# Altium schematic sheet Main.SchDoc
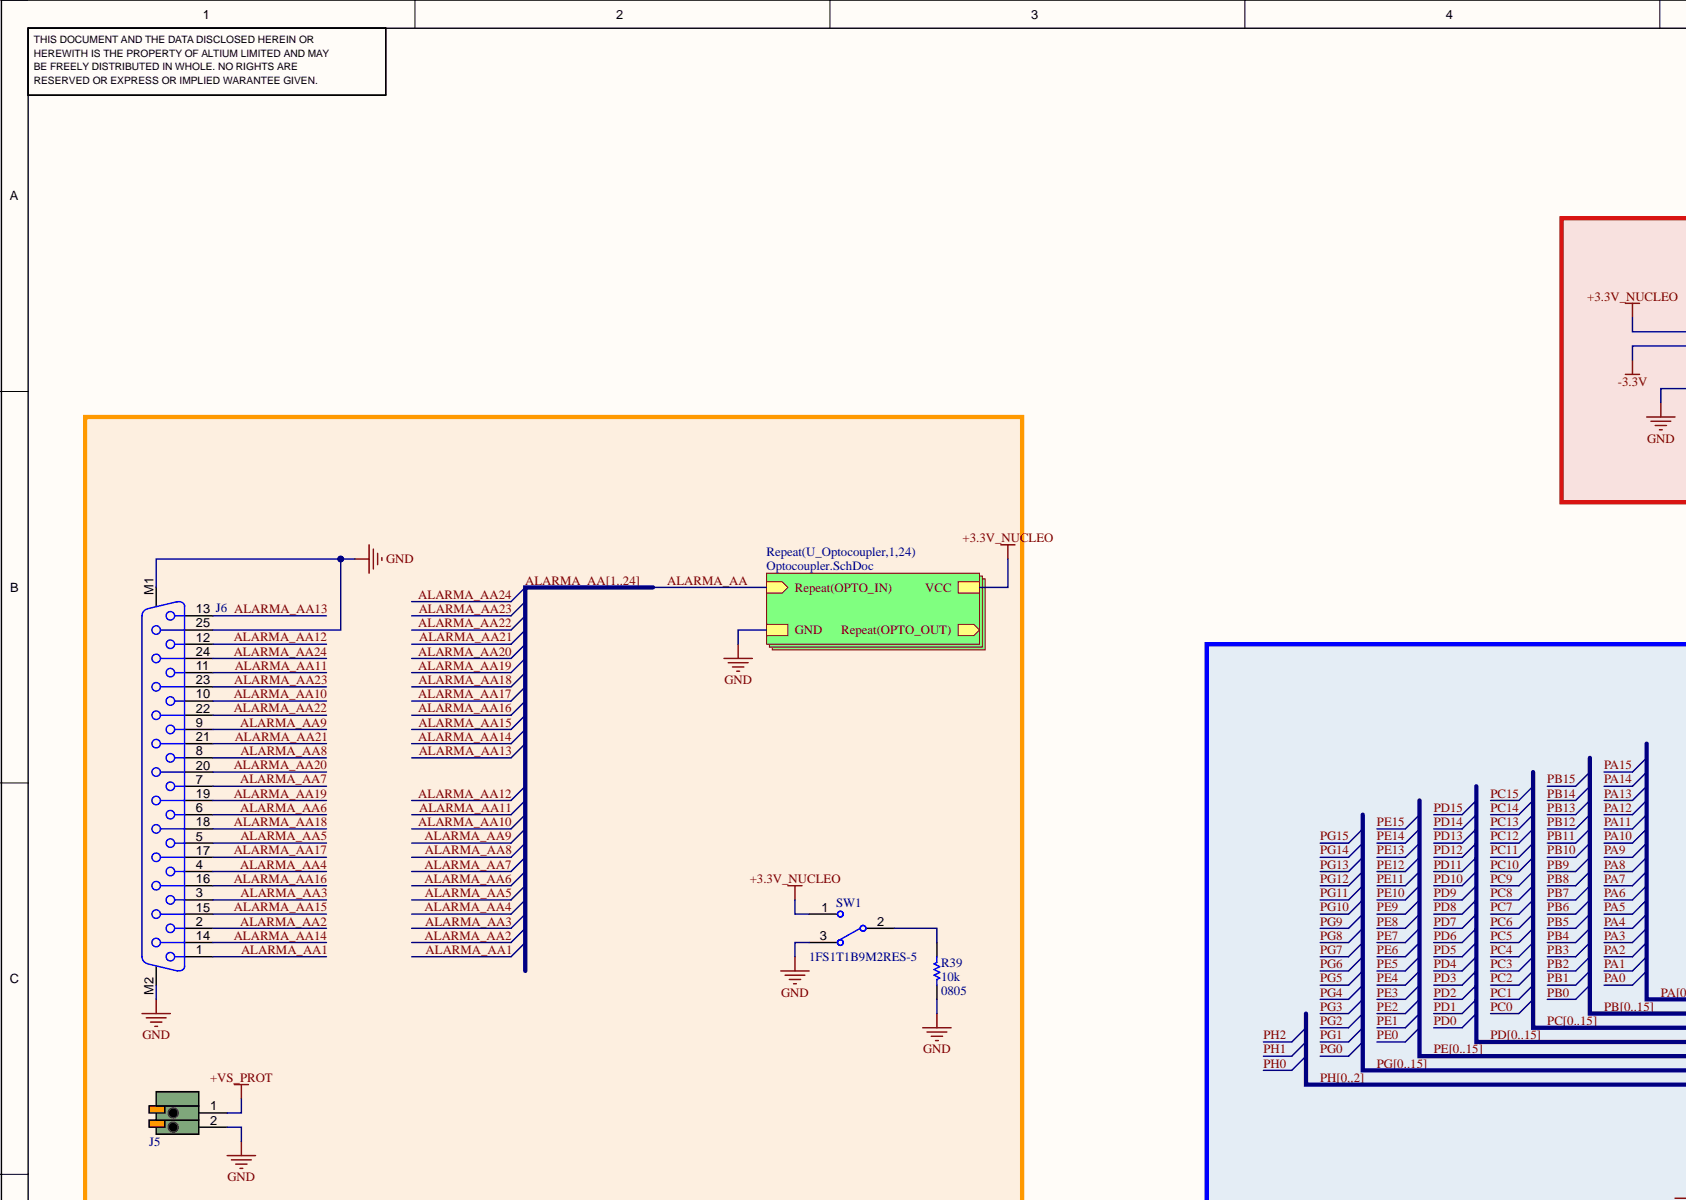
[diagram p0: Main.SchDoc, top left]
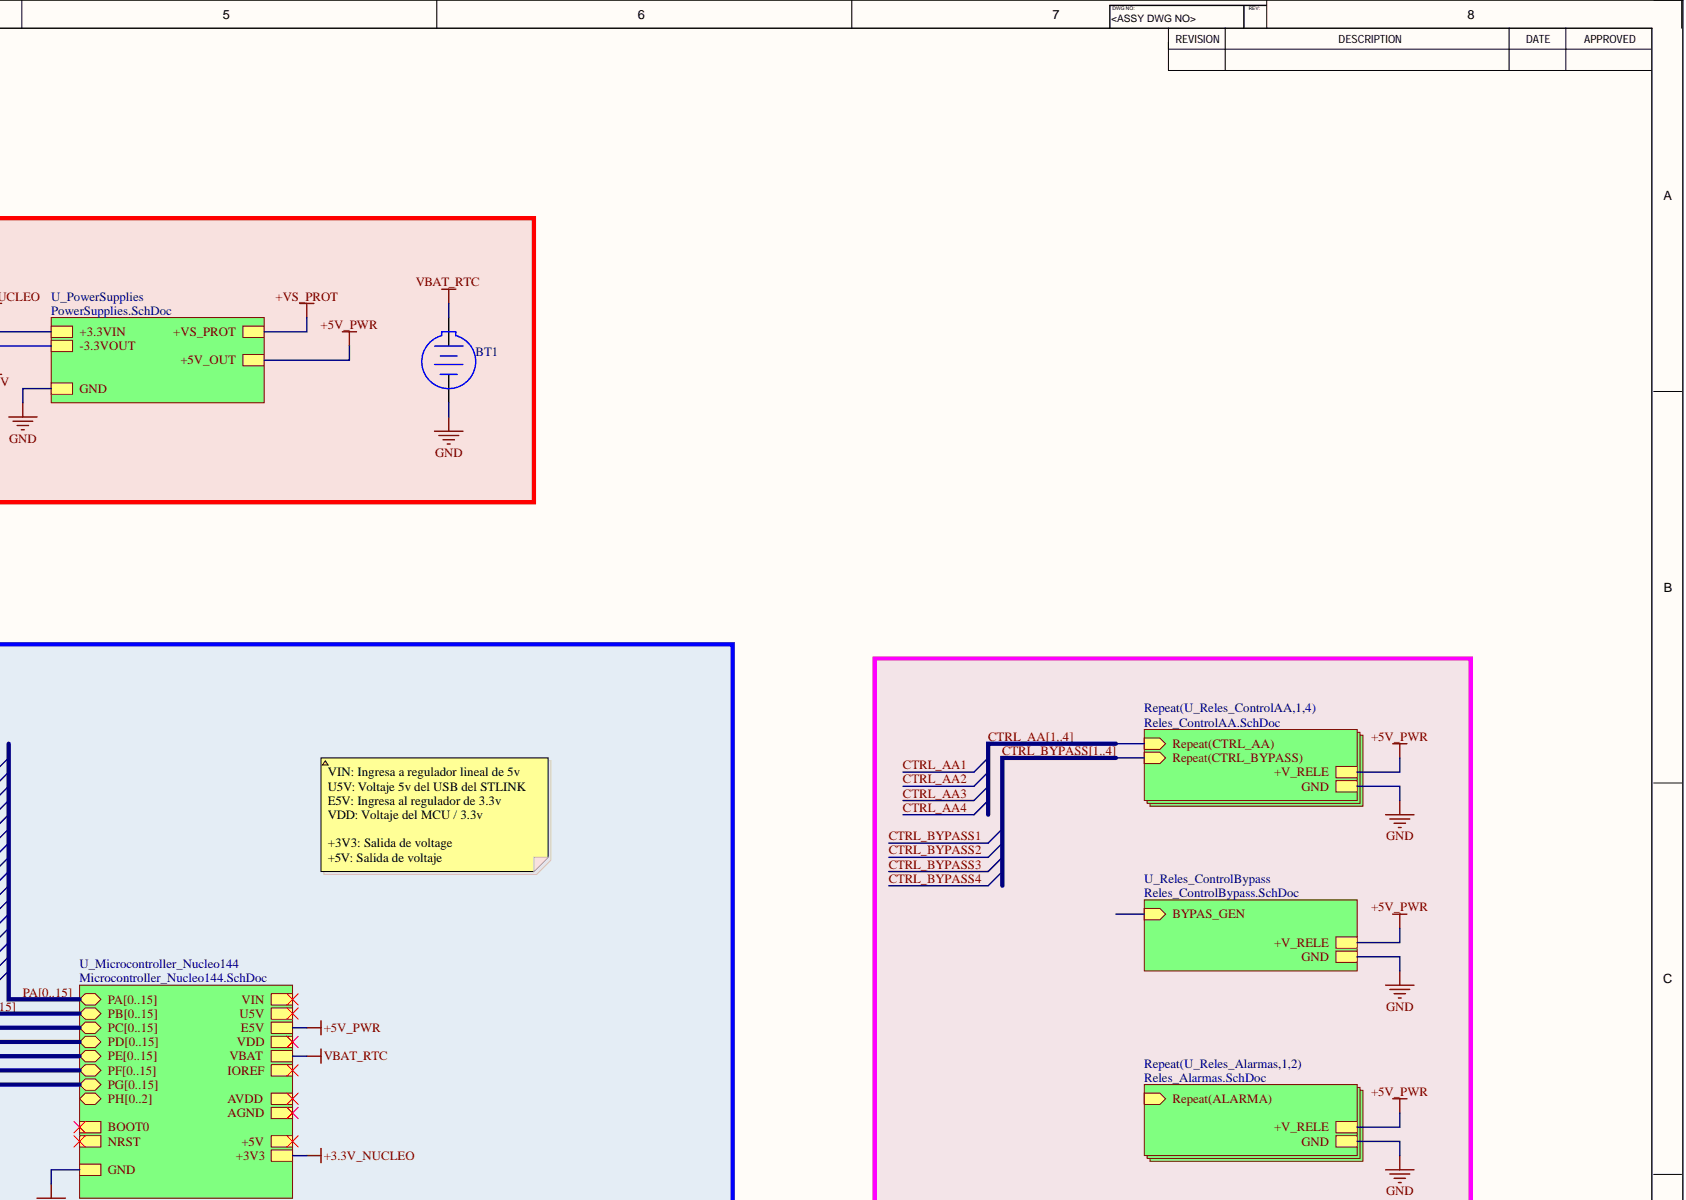
[diagram p1: Main.SchDoc, top right]
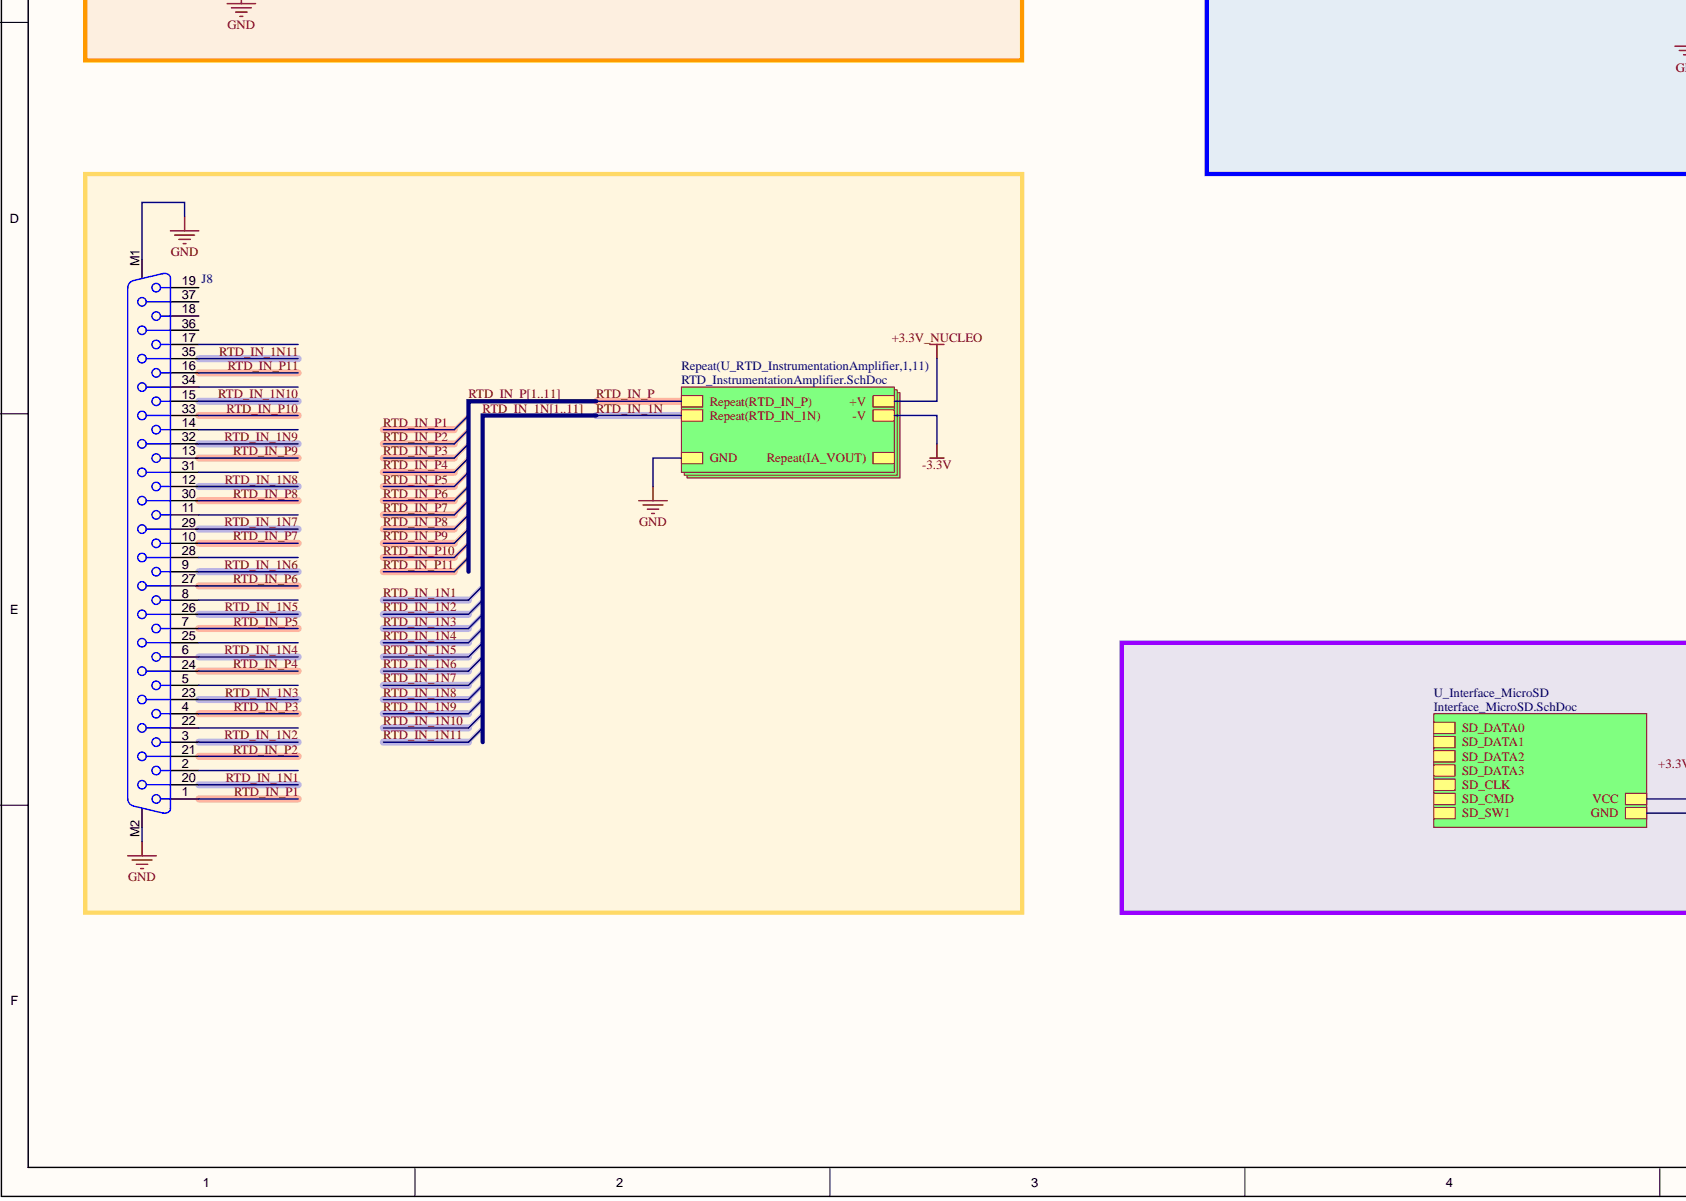
[diagram p2: Main.SchDoc, bottom left]
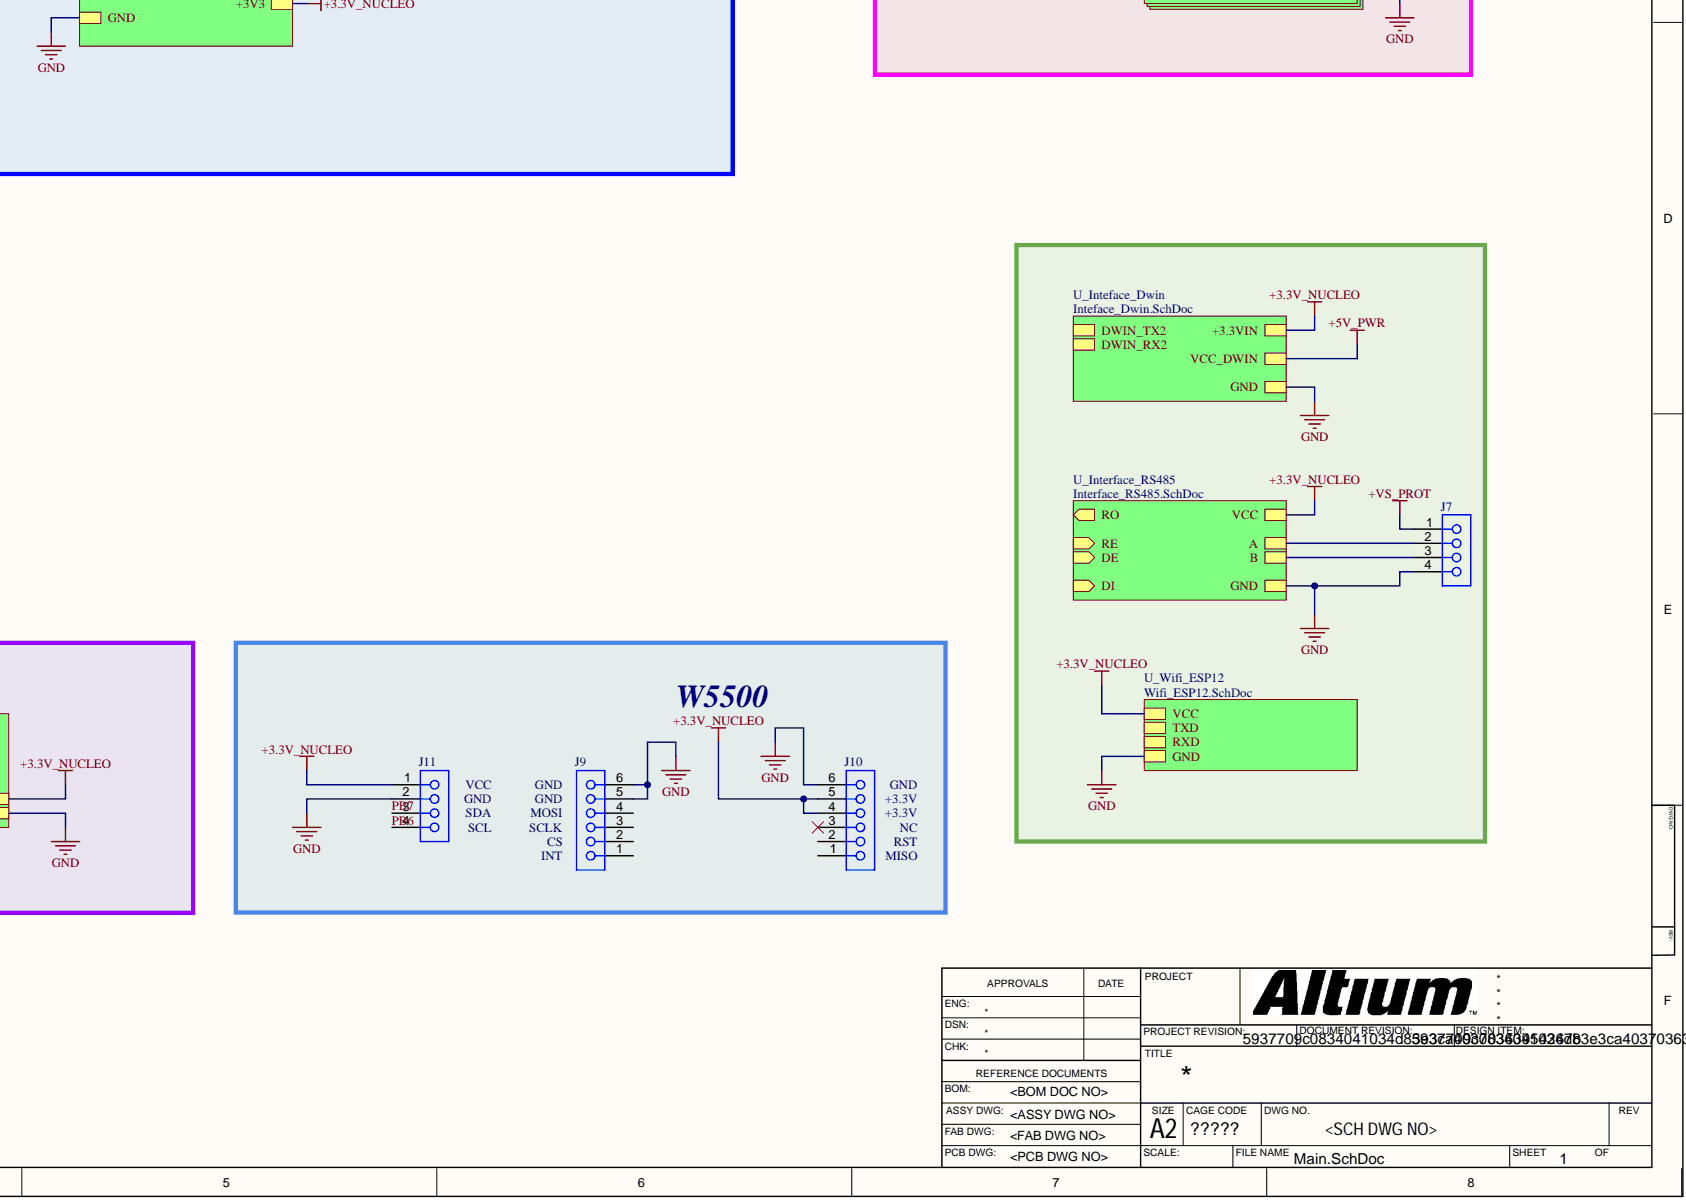
[diagram p3: Main.SchDoc, bottom right]

## Parts
BT1  BS-04-A1BJ004  [79528211]  (value not drawn)
J5  DB141V-2.54-2P-GN  [SCSTB_2.54_2CH]  (value not drawn)
J6  61802529321  [61802529321]  (value not drawn)
J7  691403900004B  [691403900004B]  (value not drawn)  (no BOM)
J8  61803729321  [61803729321]  (value not drawn)
J9  61300611821  [61300611821]  (value not drawn)  (no BOM)
J10  61300611821  [61300611821]  (value not drawn)  (no BOM)
J11  691403900004B  [691403900004B]  (value not drawn)
R39  Case/Package: 0805  Resistance: 10k  [RES0805A]  (hidden comment: Resistor 0805)
SW1  1FS1T1B9M2RES-5  [472121020311]

## Nets
+3.3V_NUCLEO: J10.4 J10.5 J11.1 SW1.1
+VS_PROT: J5.1 J7.1
GND: BT1.2 J5.2 J6.25 J6.M1 J6.M2 J7.4 J8.M1 J8.M2 J9.5 J9.6 J10.6 J11.2 R39.2 SW1.3
VBAT_RTC: BT1.1
ALARMA_AA1: J6.1  [also labelled ALARMA_AA]
ALARMA_AA2: J6.2  [also labelled ALARMA_AA]
ALARMA_AA3: J6.3  [also labelled ALARMA_AA]
ALARMA_AA4: J6.4  [also labelled ALARMA_AA]
ALARMA_AA5: J6.5  [also labelled ALARMA_AA]
ALARMA_AA6: J6.6  [also labelled ALARMA_AA]
ALARMA_AA7: J6.7  [also labelled ALARMA_AA]
ALARMA_AA8: J6.8  [also labelled ALARMA_AA]
ALARMA_AA9: J6.9  [also labelled ALARMA_AA]
ALARMA_AA10: J6.10  [also labelled ALARMA_AA]
ALARMA_AA11: J6.11  [also labelled ALARMA_AA]
ALARMA_AA12: J6.12  [also labelled ALARMA_AA]
ALARMA_AA13: J6.13  [also labelled ALARMA_AA]
ALARMA_AA14: J6.14  [also labelled ALARMA_AA]
ALARMA_AA15: J6.15  [also labelled ALARMA_AA]
ALARMA_AA16: J6.16  [also labelled ALARMA_AA]
ALARMA_AA17: J6.17  [also labelled ALARMA_AA]
ALARMA_AA18: J6.18  [also labelled ALARMA_AA]
ALARMA_AA19: J6.19  [also labelled ALARMA_AA]
ALARMA_AA20: J6.20  [also labelled ALARMA_AA]
ALARMA_AA21: J6.21  [also labelled ALARMA_AA]
ALARMA_AA22: J6.22  [also labelled ALARMA_AA]
ALARMA_AA23: J6.23  [also labelled ALARMA_AA]
ALARMA_AA24: J6.24  [also labelled ALARMA_AA]
PB6: J11.4  [also labelled PB]
PB7: J11.3  [also labelled PB]
RTD_IN_1N1: J8.20  [also labelled RTD_IN_1N]
RTD_IN_1N2: J8.3  [also labelled RTD_IN_1N]
RTD_IN_1N3: J8.23  [also labelled RTD_IN_1N]
RTD_IN_1N4: J8.6  [also labelled RTD_IN_1N]
RTD_IN_1N5: J8.26  [also labelled RTD_IN_1N]
RTD_IN_1N6: J8.9  [also labelled RTD_IN_1N]
RTD_IN_1N7: J8.29  [also labelled RTD_IN_1N]
RTD_IN_1N8: J8.12  [also labelled RTD_IN_1N]
RTD_IN_1N9: J8.32  [also labelled RTD_IN_1N]
RTD_IN_1N10: J8.15  [also labelled RTD_IN_1N]
RTD_IN_1N11: J8.35  [also labelled RTD_IN_1N]
RTD_IN_P1: J8.1  [also labelled RTD_IN_P]
RTD_IN_P2: J8.21  [also labelled RTD_IN_P]
RTD_IN_P3: J8.4  [also labelled RTD_IN_P]
RTD_IN_P4: J8.24  [also labelled RTD_IN_P]
RTD_IN_P5: J8.7  [also labelled RTD_IN_P]
RTD_IN_P6: J8.27  [also labelled RTD_IN_P]
RTD_IN_P7: J8.10  [also labelled RTD_IN_P]
RTD_IN_P8: J8.30  [also labelled RTD_IN_P]
RTD_IN_P9: J8.13  [also labelled RTD_IN_P]
RTD_IN_P10: J8.33  [also labelled RTD_IN_P]
RTD_IN_P11: J8.16  [also labelled RTD_IN_P]
NetJ7_2: J7.2
NetJ7_3: J7.3
NetJ8_2: J8.2
NetJ8_5: J8.5
NetJ8_8: J8.8
NetJ8_11: J8.11
NetJ8_14: J8.14
NetJ8_17: J8.17
NetJ8_18: J8.18
NetJ8_19: J8.19
NetJ8_22: J8.22
NetJ8_25: J8.25
NetJ8_28: J8.28
NetJ8_31: J8.31
NetJ8_34: J8.34
NetJ8_36: J8.36
NetJ8_37: J8.37
NetJ9_1: J9.1
NetJ9_2: J9.2
NetJ9_3: J9.3
NetJ9_4: J9.4
NetJ10_1: J10.1
NetJ10_2: J10.2
NetJ10_3: J10.3
NetR39_1: R39.1 SW1.2
+5V:
+5V_PWR:
-3.3V:
AGND:
AVDD:
BOOT0:
BYPAS_GEN:
CTRL_AA1:  [also labelled CTRL_AA]
CTRL_AA2:  [also labelled CTRL_AA]
CTRL_AA3:  [also labelled CTRL_AA]
CTRL_AA4:  [also labelled CTRL_AA]
CTRL_BYPASS1:  [also labelled CTRL_BYPASS]
CTRL_BYPASS2:  [also labelled CTRL_BYPASS]
CTRL_BYPASS3:  [also labelled CTRL_BYPASS]
CTRL_BYPASS4:  [also labelled CTRL_BYPASS]
DE:
DI:
DWIN_RX2:
DWIN_TX2:
IOREF:
NRST:
PA0:  [also labelled PA]
PA1:  [also labelled PA]
PA2:  [also labelled PA]
PA3:  [also labelled PA]
PA4:  [also labelled PA]
PA5:  [also labelled PA]
PA6:  [also labelled PA]
PA7:  [also labelled PA]
PA8:  [also labelled PA]
PA9:  [also labelled PA]
PA10:  [also labelled PA]
PA11:  [also labelled PA]
PA12:  [also labelled PA]
PA13:  [also labelled PA]
PA14:  [also labelled PA]
PA15:  [also labelled PA]
PB0:  [also labelled PB]
PB1:  [also labelled PB]
PB2:  [also labelled PB]
PB3:  [also labelled PB]
PB4:  [also labelled PB]
PB5:  [also labelled PB]
PB8:  [also labelled PB]
PB9:  [also labelled PB]
PB10:  [also labelled PB]
PB11:  [also labelled PB]
PB12:  [also labelled PB]
PB13:  [also labelled PB]
PB14:  [also labelled PB]
PB15:  [also labelled PB]
PC0:  [also labelled PC]
PC1:  [also labelled PC]
PC2:  [also labelled PC]
PC3:  [also labelled PC]
PC4:  [also labelled PC]
PC5:  [also labelled PC]
PC6:  [also labelled PC]
PC7:  [also labelled PC]
PC8:  [also labelled PC]
PC9:  [also labelled PC]
PC10:  [also labelled PC]
PC11:  [also labelled PC]
PC12:  [also labelled PC]
PC13:  [also labelled PC]
PC14:  [also labelled PC]
PC15:  [also labelled PC]
PD0:  [also labelled PD]
PD1:  [also labelled PD]
PD2:  [also labelled PD]
PD3:  [also labelled PD]
PD4:  [also labelled PD]
PD5:  [also labelled PD]
PD6:  [also labelled PD]
PD7:  [also labelled PD]
PD8:  [also labelled PD]
PD9:  [also labelled PD]
PD10:  [also labelled PD]
PD11:  [also labelled PD]
PD12:  [also labelled PD]
PD13:  [also labelled PD]
PD14:  [also labelled PD]
PD15:  [also labelled PD]
PE0:  [also labelled PE]
PE1:  [also labelled PE]
PE2:  [also labelled PE]
PE3:  [also labelled PE]
PE4:  [also labelled PE]
PE5:  [also labelled PE]
PE6:  [also labelled PE]
PE7:  [also labelled PE]
PE8:  [also labelled PE]
PE9:  [also labelled PE]
PE10:  [also labelled PE]
PE11:  [also labelled PE]
PE12:  [also labelled PE]
PE13:  [also labelled PE]
PE14:  [also labelled PE]
PE15:  [also labelled PE]
PG0:  [also labelled PG]
PG1:  [also labelled PG]
PG2:  [also labelled PG]
PG3:  [also labelled PG]
PG4:  [also labelled PG]
PG5:  [also labelled PG]
PG6:  [also labelled PG]
PG7:  [also labelled PG]
PG8:  [also labelled PG]
PG9:  [also labelled PG]
PG10:  [also labelled PG]
PG11:  [also labelled PG]
PG12:  [also labelled PG]
PG13:  [also labelled PG]
PG14:  [also labelled PG]
PG15:  [also labelled PG]
PH0:  [also labelled PH]
PH1:  [also labelled PH]
PH2:  [also labelled PH]
RE:
Repeat(ALARMA):
Repeat(CTRL_AA):
Repeat(CTRL_BYPASS):
Repeat(IA_VOUT):
Repeat(OPTO_OUT):
RO:
RXD:
SD_CLK:
SD_CMD:
SD_DATA0:
SD_DATA1:
SD_DATA2:
SD_DATA3:
SD_SW1:
TXD:
U5V:
VDD:
VIN:

## Library data of the parts
BT1 = 79528211: WA-BCPH Battery Holder, THT, battery type 2025,2032; Manufacturer 1: MYOUNG; Battery Type: 2025,2032; Category: Battery Holders; Component Kind: Standard; ComponentLink1Description: Website Link; ComponentLink1URL: https://www.we-online.com/redexpert/article/79528211?ad; ComponentLink2Description: Datasheet Link; ComponentLink2URL: https://www.we-online.com/redexpert/spec/79528211?ad; Library Name: WA-BCPH Battery Holder (rev22b).IntLib; Manufacturer Part Number 1: BS-04-A1BJ004; Match Code: WA-BCPH; Mount: Through Hole; Operating Temperature Max: 105°C; Operating Temperature Min: -40°C; Packaging: Tray; Supplier 1: LCSC; Supplier Part Number 1: C964750
J5 = DB141V-2.54-2P-GN: 0.2~1 20~24 2.54mm 1x2P -40℃~+105℃ 6A 130V Through Hole Green 2 1 Plugin,P=2.54mm Spring Clamp System Terminal Block ROHS; Manufacturer 1: DIBO; Component Kind: Standard; Library Name: Terminal Block.IntLib; Manufacturer Part Number 1: DB141V-2.54-2P-GN; Supplier 1: LCSC; Supplier Part Number 1: C2898744
J6 = 61802529321: WR-DSUB Female PCB Connector with Hex Screw, Straight, 25p; Manufacturer: Wurth Elektronik; Contact Resistance: 30mOhm; Rated Current: 3A; Withstanding Voltage: 1000VAC; Working Voltage: 125VAC; Category: Input/Output Connectors; Component Kind: Standard; ComponentLink1Description: Website Link; ComponentLink1URL: https://www.we-online.com/redexpert/article/61802529321?ad; ComponentLink2Description: Datasheet Link; ComponentLink2URL: https://www.we-online.com/redexpert/spec/61802529321?ad; Gender: Female; Library Name: WR-DSUB (rev23a).IntLib; Manufacturer Part Number: 61802529321; Match Code: WR-DSUB; Mount: Through Hole; Number of Pins: 25; Operating Temperature Max: 85°C; Operating Temperature Min: -40°C; Orientation: Straight; Packaging: Tray
J7 J11 = 691403900004B: WR-TBL Serie 4039 Vertical Entry, Screwless, THT, pitch 2.54mm, 4p; Manufacturer: Wurth Elektronik; Modularity: No; PCB/Cable/Panel: PCB; Pin Number: 4; Rated Current [UL]: 6A; Working Voltage [UL]: 150VAC; Application: Screwless; ComponentLink1Description: Manufacturer; ComponentLink1URL: http://www.we-online.de; ComponentLink2Description: Datasheet; ComponentLink2URL: https://katalog.we-online.de/em/datasheet/691403900004B.pdf; Manufacturer Part Number: 691403900004B; Mounting Technology: THT; Operating Temperature: -40 to +105degC; Packaging: Box; Pitch: 2.54mm; Published Date: Feburary 2021; Type: Vertical; Library Name: WR-TBL Terminal Blocks.IntLib
  J11: Component Kind: Standard
J8 = 61803729321: WR-DSUB Female PCB Connector with Hex Screw, Straight, 37p; Manufacturer: Wurth Elektronik; Contact Resistance: 30mOhm; Rated Current: 3A; Withstanding Voltage: 1000VAC; Working Voltage: 125VAC; Category: Input/Output Connectors; Component Kind: Standard; ComponentLink1Description: Website Link; ComponentLink1URL: https://www.we-online.com/redexpert/article/61803729321?ad; ComponentLink2Description: Datasheet Link; ComponentLink2URL: https://www.we-online.com/redexpert/spec/61803729321?ad; Gender: Female; Library Name: WR-DSUB (rev23a).IntLib; Manufacturer Part Number: 61803729321; Match Code: WR-DSUB; Mount: Through Hole; Number of Pins: 37; Operating Temperature Max: 85°C; Operating Temperature Min: -40°C; Orientation: Straight; Packaging: Tray
J9 J10 = 61300611821: WR-PHD Socket Header, THT, pitch 2.54mm, Single Row, Vertical, 6p; Manufacturer: Wurth Elektronik; Contact Resistance: 20mOhm; Pin Number: 6; Rated Current: 3A; Withstanding Voltage: 500VAC; Working Voltage: 250VAC; ComponentLink1Description: Manufacturer; ComponentLink1URL: http://www.we-online.de; ComponentLink2Description: Datasheet; ComponentLink2URL: https://www.we-online.com/catalog/datasheet/61300611821.pdf; Gender: Socket Header; Manufacturer Part Number: 61300611821; Mounting Technology: THT; Operating Temperature: -40 to +125degC; Orientation: Vertical; Packaging: Tray; Pitch: 2.54mm; Published Date: May 2020; Row Number: Single; Library Name: WE-WR PHD Conectors.IntLib
R39 = Resistor 0805: Power Rating: 125mW; Tolerance: 1%; Case Code (Metric): 2012; Category: Chip SMD Resistors; Component Kind: Standard; Mount: Surface Mount; Library Name: Resistencias SMD.IntLib
SW1 = 472121020311: WS-TOTV Ø 6.35mm Panel Cut-Out Vertical Operation Toggle Switch, SPDT, ON-ON, 30V, 1A; Manufacturer: Wurth Elektronik; Manufacturer 1: Dailywell; Actuator Length: 7.3mm; Bushing Length: 7.1mm; Insulation Resistance: 1000MOhm; Withstanding Voltage: 500VAC; Category: Toggle Switches; Circuit: SPDT; Component Kind: Standard; ComponentLink1Description: Website Link; ComponentLink1URL: https://www.we-online.com/redexpert/article/472121020311?ad; ComponentLink2Description: Datasheet Link; ComponentLink2URL: https://www.we-online.com/redexpert/spec/472121020311?ad; Height: 23.2mm; Length: 12.7mm; Library Name: WS-TOTV Toggle Switches (rev23b).IntLib; Manufacturer Part Number: 472121020311; Manufacturer Part Number 1: 1FS1T1B9M2RES-5; Match Code: WS-TOTV; Mount: Through Hole; Operating Temperature Max: 85°C; Operating Temperature Min: -40°C; Packaging: Tray; Rated Current: 1A; Rated Voltage (DC): 30V; Supplier 1: LCSC; Supplier Part number 1: C496164; Switching Function: ON-ON; Width: 6.6mm
